# Altium schematic sheet RTL8201F.SchDoc(RTL8201F)
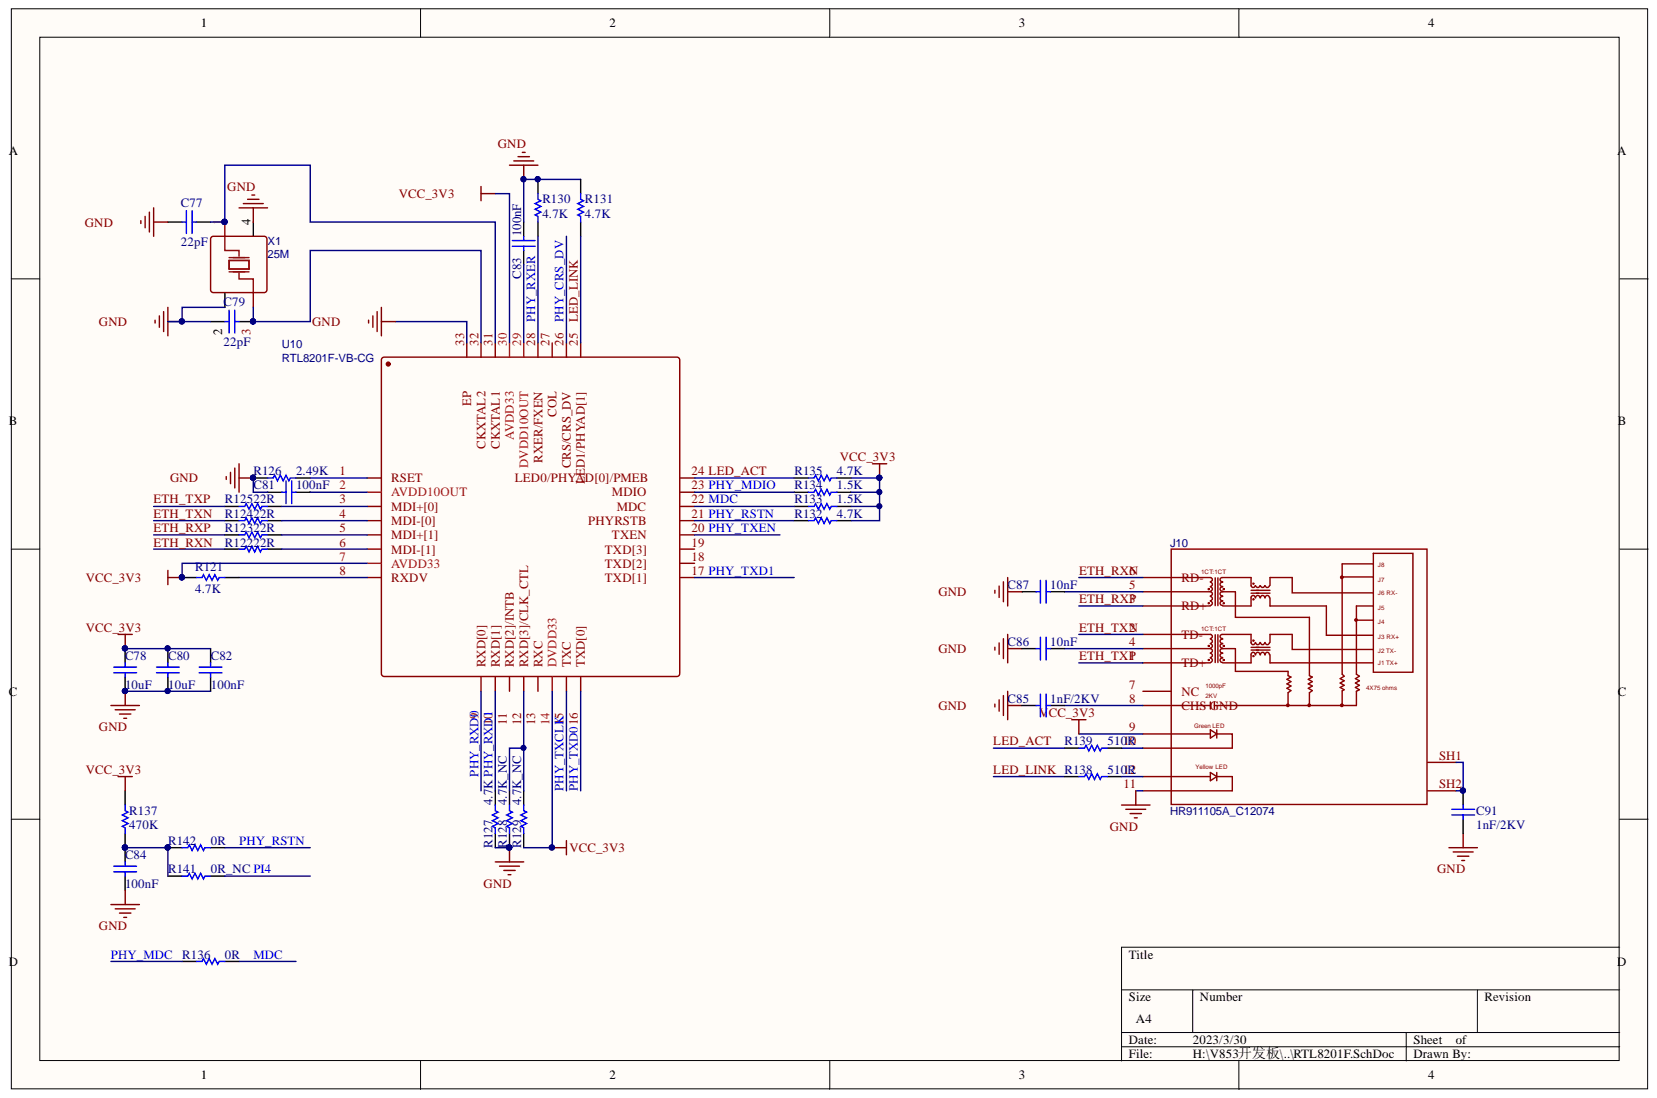
[diagram p0: RTL8201F.SchDoc]

## Parts
C77  22pF  [0402]
C78  10uF  [0402]
C79  22pF  [0402]
C80  10uF  [0402]
C81  100nF  [0402]
C82  100nF  [0402]
C83  100nF  [0402]
C84  100nF  [0402]
C85  1nF/2KV  [1206C]
C86  10nF  [0402]
C87  10nF  [0402]
C91  1nF/2KV  [1206C]
J10  HR911105A_C12074  [RJ45-TH_HR911105A]
R121  4.7K  [0402]
R122  22R  [0402]
R123  22R  [0402]
R124  22R  [0402]
R125  22R  [0402]
R126  2.49K  [0402]
R127  4.7K  [0402]
R128  4.7K_NC  [0402]
R129  4.7K_NC  [0402]
R130  4.7K  [0402]
R131  4.7K  [0402]
R132  4.7K  [0402]
R133  1.5K  [0402]
R134  1.5K  [0402]
R135  4.7K  [0402]
R136  0R  [0402]
R137  470K  [0402]
R138  510R  [0402]
R139  510R  [0402]
R141  0R_NC  [0402]
R142  0R  [0402]
U10  RTL8201F-VB-CG  [QFN-32_L5.0-W5.0-P0.50-BL-EP3.4]
X1  25M  [OSC-SMD_4P-L3.2-W2.5-BL]

## Nets
GND: C77.1 C78.1 C79.1 C80.1 C81.2 C82.2 C83.1 C84.2 C85.1 C86.1 C87.1 C91.1 J10.11 R126.2 R127.1 R128.1 R130.2 R131.2 U10.33 X1.2 X1.4
VCC_3V3: C78.2 C80.2 C82.1 J10.9 R121.2 R129.1 R132.1 R133.1 R134.1 R135.1 R137.2 U10.7(AVDD33) U10.14(DVDD33) U10.30
ETH_RXN: J10.6(RD-) R122.1
ETH_RXP: J10.3(RD+) R123.1
ETH_TXN: J10.2(TD-) R124.1
ETH_TXP: J10.1(TD+) R125.1
LED_ACT: R135.2 R139.1 U10.24
LED_LINK: R131.1 R138.1 U10.25
MDC: R133.2 R136.2 U10.22
PHY_CRS_DV: U10.26
PHY_MDC: R136.1
PHY_MDIO: R134.2 U10.23
PHY_RSTN: R132.2 R142.2 U10.21
PHY_RXD0: U10.9(RXD[0])
PHY_RXD1: R127.2 U10.10(RXD[1])
PHY_RXER: R130.1 U10.28
PHY_TXCLK: U10.15(TXC)
PHY_TXD0: U10.16(TXD[0])
PHY_TXD1: U10.17
PHY_TXEN: U10.20
PI4: R141.2
NetC77_2: C77.2 U10.31 X1.1
NetC79_2: C79.2 U10.32 X1.3
NetC81_1: C81.1 U10.2(AVDD10OUT)
NetC83_2: C83.2 U10.29
NetC84_1: C84.1 R137.1 R141.1 R142.1
NetC85_2: C85.2 J10.8(CHS_GND)
NetC86_2: C86.2 J10.4
NetC87_2: C87.2 J10.5
NetC91_2: C91.2 J10.SH1 J10.SH2
NetJ10_10: J10.10 R139.2
NetJ10_12: J10.12 R138.2
NetR121_1: R121.1 U10.8(RXDV)
NetR122_2: R122.2 U10.6(MDI-[1])
NetR123_2: R123.2 U10.5(MDI+[1])
NetR124_2: R124.2 U10.4(MDI-[0])
NetR125_2: R125.2 U10.3(MDI+[0])
NetR126_1: R126.1 U10.1(RSET)
NetR128_2: R128.2 R129.2 U10.12(RXD[3]/CLK_CTL)

Not connected: J10 7(NC); U10 11(RXD[2]/INTB) 13(RXC) 18 19 27

## Library data of the parts
C77-C87 C91 = Cap: Capacitor; PartNumber: CAP100NF; Component Kind: Standard; LatestRevisionDate: 17-Jul-2002; LatestRevisionNote: Re-released for DXP Platform.; Library Name: Miscellaneous Devices.IntLib; PackageReference: 0402; Published: 8-Jun-2000; Signal Integrity: Cap; Simulation: CAP; Publisher: Altium Limited
  C77 C79: Value: 22pF
  C78 C80: Value: 10uF
  C81-C84: Value: 100nF
  C85 C91: Value: 1nF/2KV
  C86 C87: Value: 10nF
J10 = HR911105A_C12074: BOM_Manufacturer: HANRUN; BOM_Manufacturer Part: HR911105A; BOM_Supplier: LCSC; BOM_Supplier Part: C12074; Component Kind: Standard; image: //image.lceda.cn/szlcsc/C12074.jpg; Library Name: HM2.SchLib; spicePre: J; spiceSymbolName: HR911105A_C12074
R121-R135 R137 = Res1: Resistor; PartNumber: RES2.2K; Component Kind: Standard; LatestRevisionDate: 17-Jul-2002; LatestRevisionNote: Re-released for DXP Platform.; Library Name: Miscellaneous Devices.IntLib; PackageReference: 0402; Published: 8-Jun-2000; Signal Integrity: Res; Simulation: RESISTOR; Publisher: Altium Limited
  R121 R127 R130-R132 R135: Value: 4.7K
  R122-R125: Value: 22R
  R126: Value: 2.49K
  R128 R129: Value: 4.7K_NC
  R133 R134: Value: 1.5K
  R137: Value: 470K
R136 R138 R139 R141 R142 = Res1: Resistor; PartNumber: RES22R; Component Kind: Standard; LatestRevisionDate: 17-Jul-2002; LatestRevisionNote: Re-released for DXP Platform.; Library Name: Miscellaneous Devices.IntLib; PackageReference: 0402; Published: 8-Jun-2000; Signal Integrity: Res; Simulation: RESISTOR; Publisher: Altium Limited
  R136 R142: Value: 0R
  R138 R139: Value: 510R
  R141: Value: 0R_NC
U10 = RTL8201F-VB-CG: BOM_Manufacturer: REALTEK; BOM_JLCPCB Part Class: Extended Part; BOM_Manufacturer Part: RTL8201F-VB-CG; BOM_Supplier: LCSC; BOM_Supplier Part: C45044; Component Kind: Standard; spicePre: U; spiceSymbolName: RTL8201F-VB-CG
X1 = 25M: BOM_Manufacturer: TKD; BOM_Manufacturer Part: SX32Y025000BA1T; Contributor: LCSC; nameAlias: Frequency; BOM_JLCPCB Part Class: Extended Part; BOM_Supplier: LCSC; BOM_Supplier Part: C271631; Component Kind: Standard; Library Name: HM2.SchLib; spicePre: X; spiceSymbolName: SX32Y025000BA1T
